# Altium schematic sheet 04-Sensors.SchDoc(04-Sensors)
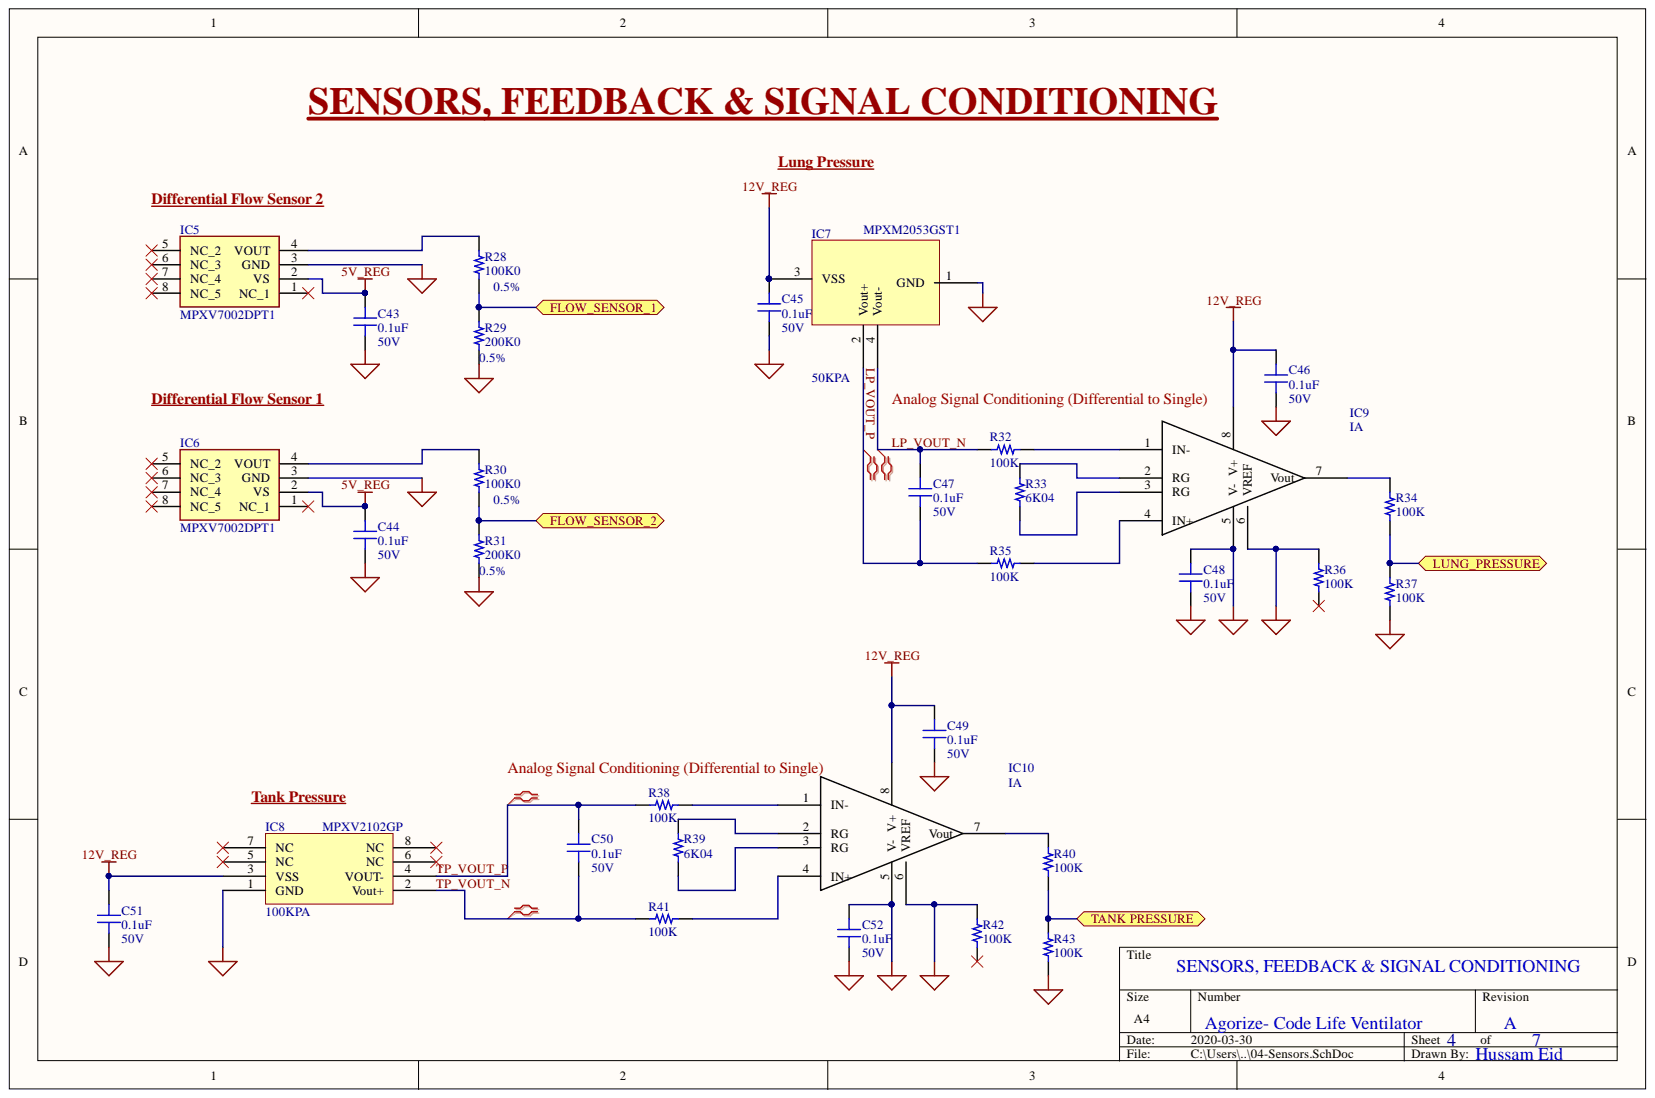
[diagram p0: 04-Sensors.SchDoc]

## Parts
C43  0.1uF  Voltage Rating (DC): 50V  [CAP 0603/1608]
C44  0.1uF  Voltage Rating (DC): 50V  [CAP 0603/1608]
C45  0.1uF  Voltage Rating (DC): 50V  [CAP 0603/1608]
C46  0.1uF  Voltage Rating (DC): 50V  [CAP 0603/1608]
C47  0.1uF  Voltage Rating (DC): 50V  [CAP 0603/1608]
C48  0.1uF  Voltage Rating (DC): 50V  [CAP 0603/1608]
C49  0.1uF  Voltage Rating (DC): 50V  [CAP 0603/1608]
C50  0.1uF  Voltage Rating (DC): 50V  [CAP 0603/1608]
C51  0.1uF  Voltage Rating (DC): 50V  [CAP 0603/1608]
C52  0.1uF  Voltage Rating (DC): 50V  [CAP 0603/1608]
IC5  MPXV7002DPT1  [MPXV7002DPT1]
IC6  MPXV7002DPT1  [MPXV7002DPT1]
IC7  50KPA  Manufacturer Part Number 1: MPXM2053GST1  [DPAK127P1219X1008-5N]
IC8  100KPA  Manufacturer Part Number 1: MPXV2102GP  [SOP127P600-8N]
IC9  IA  [SOP65P490X110-8N]
IC10  IA  [SOP65P490X110-8N]
R28  100K0  Tolerance: 0.5%  [RES 0603/1608]
R29  200K0  Tolerance: 0.5%  [RES 0603/1608]
R30  100K0  Tolerance: 0.5%  [RES 0603/1608]
R31  200K0  Tolerance: 0.5%  [RES 0603/1608]
R32  100K  [RES 0603/1608]
R33  6K04  [RES 0603/1608]
R34  100K  [RES 0603/1608]
R35  100K  [RES 0603/1608]
R36  100K  [RES 0603/1608]
R37  100K  [RES 0603/1608]
R38  100K  [RES 0603/1608]
R39  6K04  [RES 0603/1608]
R40  100K  [RES 0603/1608]
R41  100K  [RES 0603/1608]
R42  100K  [RES 0603/1608]
R43  100K  [RES 0603/1608]

## Ports
FLOW_SENSOR_1, FLOW_SENSOR_2, LUNG_PRESSURE, TANK PRESSURE

## Nets
5V_REG: C43.1 C44.1 IC5.2(VS) IC6.2(VS)
12V_REG: C45.1 C46.2 C49.2 C51.2 IC7.3(VSS) IC8.3(VSS) IC9.8(V+) IC10.8(V+)
COM: C43.2 C44.2 C45.2 C46.1 C48.1 C48.2 C49.1 C51.1 C52.1 C52.2 IC5.3(GND) IC6.3(GND) IC7.1(GND) IC8.1(GND) IC9.5(V-) IC9.6(VREF) IC10.5(V-) IC10.6(VREF) R29.1 R31.1 R36.1 R37.2 R42.1 R43.2
FLOW_SENSOR_1: R28.1 R29.2 ; port FLOW_SENSOR_1
FLOW_SENSOR_2: R30.1 R31.2 ; port FLOW_SENSOR_2
LP_VOUT_N: C47.2 IC7.4(Vout-) R32.2
LP_VOUT_P: C47.1 IC7.2(Vout+) R35.2
LUNG_PRESSURE: R34.2 R37.1 ; port LUNG_PRESSURE
TANK PRESSURE: R40.2 R43.1 ; port TANK PRESSURE
TP_VOUT_N: C50.1 IC8.2(Vout+) R41.2
TP_VOUT_P: C50.2 IC8.4(VOUT-) R38.2
NetIC5_4: IC5.4(VOUT) R28.2
NetIC6_4: IC6.4(VOUT) R30.2
NetIC9_1: IC9.1(IN-) R32.1
NetIC9_2: IC9.2(RG) R33.2
NetIC9_3: IC9.3(RG) R33.1
NetIC9_4: IC9.4(IN+) R35.1
NetIC9_7: IC9.7(Vout) R34.1
NetIC10_1: IC10.1(IN-) R38.1
NetIC10_2: IC10.2(RG) R39.2
NetIC10_3: IC10.3(RG) R39.1
NetIC10_4: IC10.4(IN+) R41.1
NetIC10_7: IC10.7(Vout) R40.1

Not connected: IC5 1(NC_1) 5(NC_2) 6(NC_3) 7(NC_4) 8(NC_5); IC6 1(NC_1) 5(NC_2) 6(NC_3) 7(NC_4) 8(NC_5); IC8 5(NC) 6(NC) 7(NC) 8(NC); R36 2; R42 2

## Library data of the parts
C43-C52 = C1608X7R1E104K080AA: CAP CER 0.1UF 50V X7R 0603; Manufacturer Part Number 1: C0603C104M5RACTU; Manufacturer 1: KEMET; Tolerance: 20%; Dissipation Factor: 2.5%; Dielectric Material: Ceramic; Description 1: Cap Ceramic 0.1uF 50V X7R 20% SMD 0603 125C T/R; Database Table Name: Capacitor - Ceramic; Capacitance: 100nF; Width: 0.031inch; Voltage Rating: 50V; Thickness: 0.034inch; Termination: SMD/SMT; Supplier Part Number 1: 399-7845-1-ND; Supplier 1: Digi-Key; Stock 1: 3475152; RoHS 1: Yes; REACH SVHC: No SVHC; Radiation Hardening: No; Pricing 1: 1 = 0.10000, 10 = 0.03900, 100 = 0.01740, 500 = 0.01240, 1000 = 0.00974 (USD); Packaging: Tape and Reel; Package Quantity: 1; Mount: Surface Mount,PCB; Min Operating Temperature: -55°C; Max Operating Temperature: 125°C; Library Name: Celestial Library Database.DbLib; Length: 1.6mm; Lead Pitch: 0.7mm; Height: 0.8mm; Dielectric: X7R; Depth: 0.8mm; Contact Plating: Tin,Matte; ComponentLink16URL: http://www.farnell.com/datasheets/2746056.pdf?src-supplier=Element14; ComponentLink16Description: http://www.farnell.com/datasheets/2746056.pdf?src-supplier=Element14; ComponentLink15URL: http://www.farnell.com/datasheets/2315113.pdf?src-supplier=Newark; ComponentLink15Description: http://www.farnell.com/datasheets/2315113.pdf?src-supplier=Newark; ComponentLink14URL: http://capacitoredge.kemet.com/capedge2/DataSheet?pn=C0603C104M5RAC7867&src-supplier=Avnet; ComponentLink14Description: http://capacitoredge.kemet.com/capedge2/DataSheet?pn=C0603C104M5RAC7867&src-supplier=Avnet; ComponentLink13URL: https://www.verical.com/server-webapp/html/getDatasheet?partID=110138&src-supplier=Verical; ComponentLink13Description: https://www.verical.com/server-webapp/html/getDatasheet?partID=110138&src-supplier=Verical; ComponentLink12URL: https://api.kemet.com/component-edge/download/datasheet/C0603C104M5RACTU.pdf?src-supplier=Digi-Key; ComponentLink12Description: https://api.kemet.com/component-edge/download/datasheet/C0603C104M5RACTU.pdf?src-supplier=Digi-Key; ComponentLink11URL: http://capacitoredge.kemet.com/capedge2/DataSheet?pn=C0603C104M5RAC7867&src-supplier=Avnet+Asia; ComponentLink11Description: http://capacitoredge.kemet.com/capedge2/DataSheet?pn=C0603C104M5RAC7867&src-supplier=Avnet+Asia; ComponentLink10URL: http://www.farnell.com/datasheets/1828800.pdf?src-supplier=Newark; ComponentLink10Description: http://www.farnell.com/datasheets/1828800.pdf?src-supplier=Newark; ComponentLink9URL: http://www.farnell.com/datasheets/2746056.pdf?src-supplier=Newark; ComponentLink9Description: http://www.farnell.com/datasheets/2746056.pdf?src-supplier=Newark; ComponentLink8URL: http://components.arrow.com/part/dataview/40911037S8853915N7429?src-supplier=Arrow; ComponentLink8Description: http://components.arrow.com/part/dataview/40911037S8853915N7429?src-supplier=Arrow; ComponentLink7URL: http://www.alliedelec.com/m/d/6f3b5eb83d09db50ed28bdc730567b33.pdf?src-supplier=Allied+Electronics; ComponentLink7Description: http://www.alliedelec.com/m/d/6f3b5eb83d09db50ed28bdc730567b33.pdf?src-supplier=Allied+Electronics; ComponentLink6URL: http://www.mouser.com/ds/2/212/KEM_C1002_X7R_SMD-1102033.pdf?src-supplier=Mouser; ComponentLink6Description: http://www.mouser.com/ds/2/212/KEM_C1002_X7R_SMD-1102033.pdf?src-supplier=Mouser; ComponentLink5URL: http://components.arrow.com/part/dataview/0323_00313986?src-supplier=Arrow+Europe; ComponentLink5Description: http://components.arrow.com/part/dataview/0323_00313986?src-supplier=Arrow+Europe; ComponentLink4URL: http://www.farnell.com/datasheets/2746056.pdf?src-supplier=Farnell; ComponentLink4Description: http://www.farnell.com/datasheets/2746056.pdf?src-supplier=Farnell; ComponentLink3URL: https://www.verical.com/server-webapp/html/getDatasheet?partID=626892&src-supplier=Verical; ComponentLink3Description: https://www.verical.com/server-webapp/html/getDatasheet?partID=626892&src-supplier=Verical; ComponentLink2URL: http://digikey.com/product-detail/en/C1608X7R1E104K080AA/445-1316-1-ND/567697; ComponentLink2Description: Digi-Key listing; ComponentLink1URL: https://product.tdk.com/info/en/catalog/datasheets/mlcc_commercial_general_en.pdf; ComponentLink1Description: Datasheet; Component Kind: Standard; Case Code (Metric): 1608; Case Code (Imperial): 0603; Case/Package: 0603
IC5 IC6 = MPXV7002DPT1: PRESSURE SENSOR DUAL PORT 8SOP; Manufacturer_Name: NXP; Manufacturer 1: NXP USA Inc.; Mouser Price/Stock: https://www.mouser.com/Search/Refine.aspx?Keyword=841-MPXV7002DPT1; Mouser Part Number: 841-MPXV7002DPT1; Manufacturer Part Number 1: MPXV7002DPT1; Manufacturer_Part_Number: MPXV7002DPT1; Height: 9.906mm; Datasheet Link: http://www.nxp.com/docs/en/data-sheet/MPXV7002.pdf; Component Kind: Standard
IC7 = Pressure Sensor: SENS PRESSURE 7.25 PSI MAX MPAK; Manufacturer 1: NXP Freescale; Pressure Type: Vented Gauge; Port Style: Barbless; Output Voltage: 40mV; Output Type: Wheatstone Bridge; Operating Supply Voltage: 10V; Nominal Supply Current: 6mA; Max Supply Voltage: 16V; Max Pressure: 29.01PSI; Description 1: Pressure Sensor 0V to 0.04V 0kPa to 50kPa Absolute Automotive 5-Pin(4+Tab) M-PAC T/R; Accuracy: 0.4%; Weight: 0.018618oz; Termination: PCB; Supplier Part Number 1: 568-13748-1-ND; Supplier 1: Digi-Key; Stock 1: 1331; RoHS 1: Yes; Pricing 1: 1 = 11.78000, 5 = 10.33200, 10 = 9.29900, 25 = 8.26600, 50 = 7.64600, 100 = 6.61270 (USD); Packaging: Tape and Reel; Package Quantity: 400; Operating Pressure: 7.25PSI; Mount: Surface Mount; Min Operating Temperature: -40°C; Max Operating Temperature: 125°C; Library Name: Schlib1.SchLib; Lead Free: Lead Free; Halogen Free: Halogen Free; ComponentLink3URL: https://datasheet.ciiva.com/16463/mpx2053-16463915.pdf?src-supplier=Future+Electronics; ComponentLink3Description: https://datasheet.ciiva.com/16463/mpx2053-16463915.pdf?src-supplier=Future+Electronics; ComponentLink2URL: https://datasheet.ciiva.com/2843/mpx2053-2843368.pdf?src-supplier=Digi-Key; ComponentLink2Description: https://datasheet.ciiva.com/2843/mpx2053-2843368.pdf?src-supplier=Digi-Key; ComponentLink1URL: https://datasheet.ciiva.com/3272/mpx2053-3272653.pdf?src-supplier=Avnet; ComponentLink1Description: https://datasheet.ciiva.com/3272/mpx2053-3272653.pdf?src-supplier=Avnet; Component Kind: Standard; Case/Package: SMD/SMT
IC8 = 100KPA Pressure Sensor: SENSOR GAUGE PRESS 14.5PSI MAX; Manufacturer 1: NXP Freescale; Voltage Rating (DC): 10V; Pressure Type: Vented Gauge; Port Style: Barbed; Output Voltage: 40mV; Output Type: Wheatstone Bridge; Nominal Supply Current: 6mA; Max Supply Voltage: 16V; Max Pressure: 58.02PSI; Description 1: Pressure Sensor 14.5 PSI (100 kPa) Vented Gauge Male - 0.13" (3.17mm) Tube 0 mV ~ 40 mV (10V) 8-SMD Module; Accuracy: 0.5%; Weight: 0.061909oz; Termination: PCB; Supplier Part Number 1: MPXV2102GP-ND; Supplier 1: Digi-Key; Stock 1: 406; RoHS 1: Yes; Pricing 1: 1 = 13.86000, 5 = 13.57400, 10 = 11.31200, 25 = 10.46360, 50 = 10.18080, 100 = 8.76680, 500 = 8.20120, 1000 = 7.77700, 5000 = 7.21140 (USD); Packaging: Tray; Package Quantity: 125; Operating Supply Voltage: 10V; Operating Pressure: 14.5PSI; Number of Pins: 8; Mount: Surface Mount; Min Operating Temperature: -40°C; Max Operating Temperature: 125°C; Library Name: Schlib1.SchLib; Lead Free: Lead Free; Halogen Free: Halogen Free; ComponentLink3URL: https://datasheet.ciiva.com/2843/mpx2102-2843513.pdf?src-supplier=Digi-Key; ComponentLink3Description: https://datasheet.ciiva.com/2843/mpx2102-2843513.pdf?src-supplier=Digi-Key; ComponentLink2URL: https://datasheet.ciiva.com/26022/mpx2102-26022587.pdf?src-supplier=Avnet+Europe; ComponentLink2Description: https://datasheet.ciiva.com/26022/mpx2102-26022587.pdf?src-supplier=Avnet+Europe; ComponentLink1URL: https://datasheet.ciiva.com/3272/mpx2102-3272661.pdf?src-supplier=Avnet; ComponentLink1Description: https://datasheet.ciiva.com/3272/mpx2102-3272661.pdf?src-supplier=Avnet; Component Kind: Standard; Case/Package: SOP
IC9 IC10 = IA: IC INST AMP 1 CIRCUIT 8VSSOP; Manufacturer Part Number 1: INA826AIDGKR; Manufacturer 1: Texas Instruments; Voltage Gain: 60dB; Slew Rate: 1V/µs; Quiescent Current: 200uA; Power Supply Rejection Ratio (PSRR): 100dB; Output Current per Channel: 16mA; Number of Elements: 1; Min Supply Voltage: 2.7V; Min Dual Supply Voltage: 1.35V; Max Supply Voltage: 36V; Max Dual Supply Voltage: 18V; Input Voltage Noise Density: 18nV/sqrt Hz; Input Resistance: 100kR; Input Offset Voltage (Vos): 150uV; Input Bias Current: 65nA; Description 1: TEXAS INSTRUMENTS - INA826AIDGKR - ?????, 1????, 40 µV, 1 V/µs, 1 MHz, 2.7V ? 36V, ± 1.35V ? ± 18V, VSSOP; Common Mode Rejection Ratio: 84dB; Bandwidth: 1MHz; Weight: 0.000935oz; Supplier Part Number 1: 296-29663-1-ND; Supplier 1: Digi-Key; Stock 1: 17777; RoHS 1: Yes; REACH SVHC: No SVHC; Radiation Hardening: No; Pricing 1: 1 = 2.73000, 10 = 2.44900, 25 = 2.31000, 100 = 1.84800, 250 = 1.61700, 500 = 1.57080, 1000 = 1.24740 (USD); Packaging: Tape and Reel; Package Quantity: 2500; Operating Supply Current: 200uA; Number of Pins: 8; Number of Circuits: 1; Number of Channels: 1; Number of Amplifiers: 1; Min Operating Temperature: -40°C; Max Operating Temperature: 125°C; Library Name: Schlib1.SchLib; Lead Free: Lead Free; Contact Plating: Gold; ComponentLink5URL: https://datasheet.ciiva.com/14338/ina826-14338024.pdf?src-supplier=Avnet; ComponentLink5Description: https://datasheet.ciiva.com/14338/ina826-14338024.pdf?src-supplier=Avnet; ComponentLink4URL: https://datasheet.ciiva.com/1798/ina826-1798296.pdf?src-supplier=Digi-Key; ComponentLink4Description: https://datasheet.ciiva.com/1798/ina826-1798296.pdf?src-supplier=Digi-Key; ComponentLink3URL: https://datasheet.ciiva.com/1798/ina826-1798295.pdf?src-supplier=Digi-Key; ComponentLink3Description: https://datasheet.ciiva.com/1798/ina826-1798295.pdf?src-supplier=Digi-Key; ComponentLink2URL: https://datasheet.ciiva.com/1798/ina826-1798294.pdf?src-supplier=Digi-Key; ComponentLink2Description: https://datasheet.ciiva.com/1798/ina826-1798294.pdf?src-supplier=Digi-Key; ComponentLink1URL: https://datasheet.ciiva.com/1487/1806064-1487618.pdf?src-supplier=Element14; ComponentLink1Description: https://datasheet.ciiva.com/1487/1806064-1487618.pdf?src-supplier=Element14; Component Kind: Standard; Case/Package: VSSOP; -3db Bandwidth: 1MHz
R28 R30 = ERJ-3EKF1001V: RES SMD 100K OHM 0.5% 1/16W 0603; Manufacturer Part Number 1: RR0816P-104-D; Manufacturer 1: Susumu; Working Voltage: 75V; Temperature Coefficient: 25ppm/°C; Resistance: 100kR; Power Rating: 63mW; Database Table Name: Resistor - Chip; Width: 0.8mm; Voltage Rating (DC): 75V; Voltage Rating: 75V; Termination: SMD/SMT; Supplier Part Number 1: RR08P100KDCT-ND; Supplier 1: Digi-Key; Stock 1: 90889; RoHS 1: Yes; REACH SVHC: Unknown; Pricing 1: 1 = 0.10000, 10 = 0.09300, 100 = 0.03580, 1000 = 0.01575, 2500 = 0.01360 (USD); Packaging: Tape and Reel; Package Quantity: 1; Number of Terminations: 2; Number of Pins: 2; Min Operating Temperature: -55°C; Max Power Dissipation: 63mW; Max Operating Temperature: 125°C; Library Name: Celestial Library Database.DbLib; Length: 1.6mm; Lead Free: Lead Free; Height: 0.4mm; Description 1: RES SMD 100K OHM 0.5% 1/16W 0603; Depth: 0.8mm; Composition: Thin Film; ComponentLink14URL: https://datasheet.ciiva.com/30199/4aoa0000ce1-30199822.pdf?src-supplier=Onlinecomponents.com; ComponentLink14Description: https://datasheet.ciiva.com/30199/4aoa0000ce1-30199822.pdf?src-supplier=Onlinecomponents.com; ComponentLink13URL: https://datasheet.ciiva.com/17215/aoa0000ce1-17215388.pdf?src-supplier=Avnet+Europe; ComponentLink13Description: https://datasheet.ciiva.com/17215/aoa0000ce1-17215388.pdf?src-supplier=Avnet+Europe; ComponentLink12URL: https://datasheet.ciiva.com/16834/aoa0000ce1-16834828.pdf?src-supplier=Future+Electronics; ComponentLink12Description: https://datasheet.ciiva.com/16834/aoa0000ce1-16834828.pdf?src-supplier=Future+Electronics; ComponentLink11URL: https://datasheet.ciiva.com/16758/70255313-16758527.pdf?src-supplier=Allied+Electronics; ComponentLink11Description: https://datasheet.ciiva.com/16758/70255313-16758527.pdf?src-supplier=Allied+Electronics; ComponentLink10URL: https://datasheet.ciiva.com/9198/aoa0000ce1-9198975.pdf?src-supplier=Avnet; ComponentLink10Description: https://datasheet.ciiva.com/9198/aoa0000ce1-9198975.pdf?src-supplier=Avnet; ComponentLink9URL: https://datasheet.ciiva.com/46377/1678796-46377868.pdf?src-supplier=Element14; ComponentLink9Description: https://datasheet.ciiva.com/46377/1678796-46377868.pdf?src-supplier=Element14; ComponentLink8URL: https://datasheet.ciiva.com/5959/1678796-5959707.pdf?src-supplier=Farnell; ComponentLink8Description: https://datasheet.ciiva.com/5959/1678796-5959707.pdf?src-supplier=Farnell; ComponentLink7URL: https://datasheet.ciiva.com/28695/1678796-28695833.pdf?src-supplier=Element14; ComponentLink7Description: https://datasheet.ciiva.com/28695/1678796-28695833.pdf?src-supplier=Element14; ComponentLink5URL: https://datasheet.ciiva.com/2487/rr-data-sheet-2487069.pdf?src-supplier=Digi-Key; ComponentLink5Description: https://datasheet.ciiva.com/2487/rr-data-sheet-2487069.pdf?src-supplier=Digi-Key; ComponentLink4URL: https://datasheet.ciiva.com/2487/rr-data-sheet-2487068.pdf?src-supplier=Digi-Key; ComponentLink4Description: https://datasheet.ciiva.com/2487/rr-data-sheet-2487068.pdf?src-supplier=Digi-Key; ComponentLink3URL: https://datasheet.ciiva.com/2487/rr-data-sheet-2487067.pdf?src-supplier=Digi-Key; ComponentLink3Description: https://datasheet.ciiva.com/2487/rr-data-sheet-2487067.pdf?src-supplier=Digi-Key; ComponentLink2URL: https://datasheet.ciiva.com/7984/87330-7984341.pdf?src-supplier=Element14; ComponentLink2Description: https://datasheet.ciiva.com/7984/87330-7984341.pdf?src-supplier=Element14; ComponentLink1URL: https://datasheet.ciiva.com/11820/0900766b80808d64-11820638.pdf?src-supplier=RSComponents; ComponentLink1Description: https://datasheet.ciiva.com/11820/0900766b80808d64-11820638.pdf?src-supplier=RSComponents; Component Kind: Standard; Case Code (Metric): 1608; Case Code (Imperial): 0603; Case/Package: 0603
R29 R31 = ERJ-3EKF1001V: RES SMD 200K OHM 0.5% 1/16W 0603; Manufacturer Part Number 1: RR0816P-204-D; Manufacturer 1: Susumu; Working Voltage: 75V; Temperature Coefficient: 25ppm/°C; Resistance: 200kR; Power Rating: 63mW; Database Table Name: Resistor - Chip; Width: 0.8mm; Voltage Rating (DC): 75V; Voltage Rating: 75V; Termination: SMD/SMT; Supplier Part Number 1: RR08P200KDCT-ND; Supplier 1: Digi-Key; Stock 1: 54417; RoHS 1: Yes; REACH SVHC: Unknown; Pricing 1: 1 = 0.11000, 10 = 0.09800, 100 = 0.03760, 1000 = 0.01654, 2500 = 0.01428 (USD); Packaging: Tape and Reel; Number of Terminations: 2; Number of Pins: 2; Min Operating Temperature: -55°C; Max Operating Temperature: 125°C; Library Name: Celestial Library Database.DbLib; Length: 1.6mm; Lead Free: Lead Free; Height: 0.4mm; Description 1: RES SMD 200K OHM 0.5% 1/16W 0603; Depth: 0.8mm; Composition: Thin Film; ComponentLink15URL: https://datasheet.ciiva.com/7984/87330-7984334.pdf?src-supplier=Element14; ComponentLink15Description: https://datasheet.ciiva.com/7984/87330-7984334.pdf?src-supplier=Element14; ComponentLink14URL: https://datasheet.ciiva.com/30199/4aoa0000ce1-30199822.pdf?src-supplier=Onlinecomponents.com; ComponentLink14Description: https://datasheet.ciiva.com/30199/4aoa0000ce1-30199822.pdf?src-supplier=Onlinecomponents.com; ComponentLink13URL: https://datasheet.ciiva.com/17215/aoa0000ce1-17215388.pdf?src-supplier=Avnet+Europe; ComponentLink13Description: https://datasheet.ciiva.com/17215/aoa0000ce1-17215388.pdf?src-supplier=Avnet+Europe; ComponentLink12URL: https://datasheet.ciiva.com/16834/aoa0000ce1-16834828.pdf?src-supplier=Future+Electronics; ComponentLink12Description: https://datasheet.ciiva.com/16834/aoa0000ce1-16834828.pdf?src-supplier=Future+Electronics; ComponentLink11URL: https://datasheet.ciiva.com/16758/70255313-16758527.pdf?src-supplier=Allied+Electronics; ComponentLink11Description: https://datasheet.ciiva.com/16758/70255313-16758527.pdf?src-supplier=Allied+Electronics; ComponentLink10URL: https://datasheet.ciiva.com/9198/aoa0000ce1-9198975.pdf?src-supplier=Avnet; ComponentLink10Description: https://datasheet.ciiva.com/9198/aoa0000ce1-9198975.pdf?src-supplier=Avnet; ComponentLink9URL: https://datasheet.ciiva.com/46377/1678796-46377868.pdf?src-supplier=Element14; ComponentLink9Description: https://datasheet.ciiva.com/46377/1678796-46377868.pdf?src-supplier=Element14; ComponentLink8URL: https://datasheet.ciiva.com/5959/1678796-5959707.pdf?src-supplier=Farnell; ComponentLink8Description: https://datasheet.ciiva.com/5959/1678796-5959707.pdf?src-supplier=Farnell; ComponentLink7URL: https://datasheet.ciiva.com/28695/1678796-28695833.pdf?src-supplier=Element14; ComponentLink7Description: https://datasheet.ciiva.com/28695/1678796-28695833.pdf?src-supplier=Element14; ComponentLink6URL: https://datasheet.ciiva.com/11820/0900766b80808d64-11820394.pdf?src-supplier=RSComponents; ComponentLink6Description: https://datasheet.ciiva.com/11820/0900766b80808d64-11820394.pdf?src-supplier=RSComponents; ComponentLink5URL: https://datasheet.ciiva.com/2487/rr-data-sheet-2487069.pdf?src-supplier=Digi-Key; ComponentLink5Description: https://datasheet.ciiva.com/2487/rr-data-sheet-2487069.pdf?src-supplier=Digi-Key; ComponentLink4URL: https://datasheet.ciiva.com/2487/rr-data-sheet-2487068.pdf?src-supplier=Digi-Key; ComponentLink4Description: https://datasheet.ciiva.com/2487/rr-data-sheet-2487068.pdf?src-supplier=Digi-Key; ComponentLink3URL: https://datasheet.ciiva.com/2487/rr-data-sheet-2487067.pdf?src-supplier=Digi-Key; ComponentLink3Description: https://datasheet.ciiva.com/2487/rr-data-sheet-2487067.pdf?src-supplier=Digi-Key; ComponentLink2URL: https://datasheet.ciiva.com/7984/87330-7984341.pdf?src-supplier=Element14; ComponentLink2Description: https://datasheet.ciiva.com/7984/87330-7984341.pdf?src-supplier=Element14; ComponentLink1URL: https://datasheet.ciiva.com/11820/0900766b80808d64-11820638.pdf?src-supplier=RSComponents; ComponentLink1Description: https://datasheet.ciiva.com/11820/0900766b80808d64-11820638.pdf?src-supplier=RSComponents; Component Kind: Standard; Case Code (Metric): 1608; Case Code (Imperial): 0603; Case/Package: 0603
R32 R34-R38 R40-R43 = ERJ-3EKF1001V: RES SMD100K OHM 0.5% 1/16W 0603; Manufacturer Part Number 1: RR0816P-104-D; Manufacturer 1: Susumu; Working Voltage: 75V; Temperature Coefficient: 25ppm/°C; Resistance: 100kR; Power Rating: 63mW; Database Table Name: Resistor - Chip; Width: 0.8mm; Voltage Rating (DC): 75V; Voltage Rating: 75V; Tolerance: 0.5%; Termination: SMD/SMT; Supplier Part Number 1: RR08P100KDCT-ND; Supplier 1: Digi-Key; Stock 1: 90889; RoHS 1: Yes; REACH SVHC: Unknown; Pricing 1: 1 = 0.10000, 10 = 0.09300, 100 = 0.03580, 1000 = 0.01575, 2500 = 0.01360 (USD); Packaging: Tape and Reel; Package Quantity: 1; Number of Terminations: 2; Number of Pins: 2; Min Operating Temperature: -55°C; Max Power Dissipation: 63mW; Max Operating Temperature: 125°C; Library Name: Celestial Library Database.DbLib; Length: 1.6mm; Lead Free: Lead Free; Height: 0.4mm; Description 1: RES SMD 100K OHM 0.5% 1/16W 0603; Depth: 0.8mm; Composition: Thin Film; ComponentLink15URL: https://datasheet.ciiva.com/7984/87330-7984334.pdf?src-supplier=Element14; ComponentLink15Description: https://datasheet.ciiva.com/7984/87330-7984334.pdf?src-supplier=Element14; ComponentLink14URL: https://datasheet.ciiva.com/30199/4aoa0000ce1-30199822.pdf?src-supplier=Onlinecomponents.com; ComponentLink14Description: https://datasheet.ciiva.com/30199/4aoa0000ce1-30199822.pdf?src-supplier=Onlinecomponents.com; ComponentLink13URL: https://datasheet.ciiva.com/17215/aoa0000ce1-17215388.pdf?src-supplier=Avnet+Europe; ComponentLink13Description: https://datasheet.ciiva.com/17215/aoa0000ce1-17215388.pdf?src-supplier=Avnet+Europe; ComponentLink12URL: https://datasheet.ciiva.com/16834/aoa0000ce1-16834828.pdf?src-supplier=Future+Electronics; ComponentLink12Description: https://datasheet.ciiva.com/16834/aoa0000ce1-16834828.pdf?src-supplier=Future+Electronics; ComponentLink11URL: https://datasheet.ciiva.com/16758/70255313-16758527.pdf?src-supplier=Allied+Electronics; ComponentLink11Description: https://datasheet.ciiva.com/16758/70255313-16758527.pdf?src-supplier=Allied+Electronics; ComponentLink10URL: https://datasheet.ciiva.com/9198/aoa0000ce1-9198975.pdf?src-supplier=Avnet; ComponentLink10Description: https://datasheet.ciiva.com/9198/aoa0000ce1-9198975.pdf?src-supplier=Avnet; ComponentLink9URL: https://datasheet.ciiva.com/46377/1678796-46377868.pdf?src-supplier=Element14; ComponentLink9Description: https://datasheet.ciiva.com/46377/1678796-46377868.pdf?src-supplier=Element14; ComponentLink8URL: https://datasheet.ciiva.com/5959/1678796-5959707.pdf?src-supplier=Farnell; ComponentLink8Description: https://datasheet.ciiva.com/5959/1678796-5959707.pdf?src-supplier=Farnell; ComponentLink7URL: https://datasheet.ciiva.com/28695/1678796-28695833.pdf?src-supplier=Element14; ComponentLink7Description: https://datasheet.ciiva.com/28695/1678796-28695833.pdf?src-supplier=Element14; ComponentLink6URL: https://datasheet.ciiva.com/11820/0900766b80808d64-11820394.pdf?src-supplier=RSComponents; ComponentLink6Description: https://datasheet.ciiva.com/11820/0900766b80808d64-11820394.pdf?src-supplier=RSComponents; ComponentLink5URL: https://datasheet.ciiva.com/2487/rr-data-sheet-2487069.pdf?src-supplier=Digi-Key; ComponentLink5Description: https://datasheet.ciiva.com/2487/rr-data-sheet-2487069.pdf?src-supplier=Digi-Key; ComponentLink4URL: https://datasheet.ciiva.com/2487/rr-data-sheet-2487068.pdf?src-supplier=Digi-Key; ComponentLink4Description: https://datasheet.ciiva.com/2487/rr-data-sheet-2487068.pdf?src-supplier=Digi-Key; ComponentLink3URL: https://datasheet.ciiva.com/2487/rr-data-sheet-2487067.pdf?src-supplier=Digi-Key; ComponentLink3Description: https://datasheet.ciiva.com/2487/rr-data-sheet-2487067.pdf?src-supplier=Digi-Key; ComponentLink2URL: https://datasheet.ciiva.com/7984/87330-7984341.pdf?src-supplier=Element14; ComponentLink2Description: https://datasheet.ciiva.com/7984/87330-7984341.pdf?src-supplier=Element14; ComponentLink1URL: https://datasheet.ciiva.com/11820/0900766b80808d64-11820638.pdf?src-supplier=RSComponents; ComponentLink1Description: https://datasheet.ciiva.com/11820/0900766b80808d64-11820638.pdf?src-supplier=RSComponents; Component Kind: Standard; Case Code (Metric): 1608; Case Code (Imperial): 0603; Case/Package: 0603
R33 R39 = ERJ-3EKF1001V: RES SMD 6K04 OHM 0.5% 1/16W 0603; Manufacturer Part Number 1: RMCF0603FT6K04; Manufacturer 1: Stackpole Electronics; Tolerance: 1%; Temperature Coefficient: 100ppm/°C; Resistance: 6.04kR; Power Rating: 100mW; Description 1: Res Thick Film 0603 6.04K Ohm 1% 1/10W 100ppm/C Molded SMD SMD Paper T/R; Database Table Name: Resistor - Chip; Width: 0.031inch; Supplier Part Number 1: RMCF0603FT6K04CT-ND; Supplier 1: Digi-Key; Stock 1: 57211; RoHS 1: Yes; Radiation Hardening: No; Pricing 1: 1 = 0.10000, 10 = 0.01600, 100 = 0.00650, 1000 = 0.00292, 2500 = 0.00253 (USD); Packaging: Tape and Reel; Number of Terminations: 2; Mount: Surface Mount; Min Operating Temperature: -55°C; Max Power Dissipation: 100mW; Max Operating Temperature: 155°C; Library Name: Celestial Library Database.DbLib; Length: 0.061inch; Lead Free: Lead Free; Height: 0.022inch; Features: Automotive AEC-Q200; Contact Plating: Tin,Matte; Composition: Thick Film; ComponentLink20URL: https://www.seielect.com/catalog/SEI-RMCF_RMCP.pdf?src-supplier=Avnet+Asia; ComponentLink20Description: https://www.seielect.com/catalog/SEI-RMCF_RMCP.pdf?src-supplier=Avnet+Asia; ComponentLink19URL: http://www.seielect.com/Catalog/SEI-RMC.pdf?src-supplier=Future+Electronics; ComponentLink19Description: http://www.seielect.com/Catalog/SEI-RMC.pdf?src-supplier=Future+Electronics; ComponentLink18URL: https://www.seielect.com/catalog/SEI-Packaging.pdf?src-supplier=Digi-Key; ComponentLink18Description: https://www.seielect.com/catalog/SEI-Packaging.pdf?src-supplier=Digi-Key; ComponentLink17URL: https://www.seielect.com/catalog/SEI-RMCF_RMCP.pdf?src-supplier=Avnet; ComponentLink17Description: https://www.seielect.com/catalog/SEI-RMCF_RMCP.pdf?src-supplier=Avnet; ComponentLink16URL: http://components.arrow.com/part/dataview/44987894S7815445N1174?src-supplier=Arrow; ComponentLink16Description: http://components.arrow.com/part/dataview/44987894S7815445N1174?src-supplier=Arrow; ComponentLink15URL: https://datasheet.ciiva.com/7984/87330-7984334.pdf?src-supplier=Element14; ComponentLink15Description: https://datasheet.ciiva.com/7984/87330-7984334.pdf?src-supplier=Element14; ComponentLink14URL: https://datasheet.ciiva.com/30199/4aoa0000ce1-30199822.pdf?src-supplier=Onlinecomponents.com; ComponentLink14Description: https://datasheet.ciiva.com/30199/4aoa0000ce1-30199822.pdf?src-supplier=Onlinecomponents.com; ComponentLink13URL: https://datasheet.ciiva.com/17215/aoa0000ce1-17215388.pdf?src-supplier=Avnet+Europe; ComponentLink13Description: https://datasheet.ciiva.com/17215/aoa0000ce1-17215388.pdf?src-supplier=Avnet+Europe; ComponentLink12URL: https://datasheet.ciiva.com/16834/aoa0000ce1-16834828.pdf?src-supplier=Future+Electronics; ComponentLink12Description: https://datasheet.ciiva.com/16834/aoa0000ce1-16834828.pdf?src-supplier=Future+Electronics; ComponentLink11URL: https://datasheet.ciiva.com/16758/70255313-16758527.pdf?src-supplier=Allied+Electronics; ComponentLink11Description: https://datasheet.ciiva.com/16758/70255313-16758527.pdf?src-supplier=Allied+Electronics; ComponentLink10URL: https://datasheet.ciiva.com/9198/aoa0000ce1-9198975.pdf?src-supplier=Avnet; ComponentLink10Description: https://datasheet.ciiva.com/9198/aoa0000ce1-9198975.pdf?src-supplier=Avnet; ComponentLink9URL: https://datasheet.ciiva.com/46377/1678796-46377868.pdf?src-supplier=Element14; ComponentLink9Description: https://datasheet.ciiva.com/46377/1678796-46377868.pdf?src-supplier=Element14; ComponentLink8URL: https://datasheet.ciiva.com/5959/1678796-5959707.pdf?src-supplier=Farnell; ComponentLink8Description: https://datasheet.ciiva.com/5959/1678796-5959707.pdf?src-supplier=Farnell; ComponentLink7URL: https://datasheet.ciiva.com/28695/1678796-28695833.pdf?src-supplier=Element14; ComponentLink7Description: https://datasheet.ciiva.com/28695/1678796-28695833.pdf?src-supplier=Element14; ComponentLink6URL: https://datasheet.ciiva.com/11820/0900766b80808d64-11820394.pdf?src-supplier=RSComponents; ComponentLink6Description: https://datasheet.ciiva.com/11820/0900766b80808d64-11820394.pdf?src-supplier=RSComponents; ComponentLink5URL: https://datasheet.ciiva.com/2487/rr-data-sheet-2487069.pdf?src-supplier=Digi-Key; ComponentLink5Description: https://datasheet.ciiva.com/2487/rr-data-sheet-2487069.pdf?src-supplier=Digi-Key; ComponentLink4URL: https://datasheet.ciiva.com/2487/rr-data-sheet-2487068.pdf?src-supplier=Digi-Key; ComponentLink4Description: https://datasheet.ciiva.com/2487/rr-data-sheet-2487068.pdf?src-supplier=Digi-Key; ComponentLink3URL: https://datasheet.ciiva.com/2487/rr-data-sheet-2487067.pdf?src-supplier=Digi-Key; ComponentLink3Description: https://datasheet.ciiva.com/2487/rr-data-sheet-2487067.pdf?src-supplier=Digi-Key; ComponentLink2URL: https://datasheet.ciiva.com/7984/87330-7984341.pdf?src-supplier=Element14; ComponentLink2Description: https://datasheet.ciiva.com/7984/87330-7984341.pdf?src-supplier=Element14; ComponentLink1URL: https://datasheet.ciiva.com/11820/0900766b80808d64-11820638.pdf?src-supplier=RSComponents; ComponentLink1Description: https://datasheet.ciiva.com/11820/0900766b80808d64-11820638.pdf?src-supplier=RSComponents; Component Kind: Standard; Case Code (Metric): 1608; Case Code (Imperial): 0603; Case/Package: 0603
